# Altium schematic sheet PC+dispDecoder.SchDoc
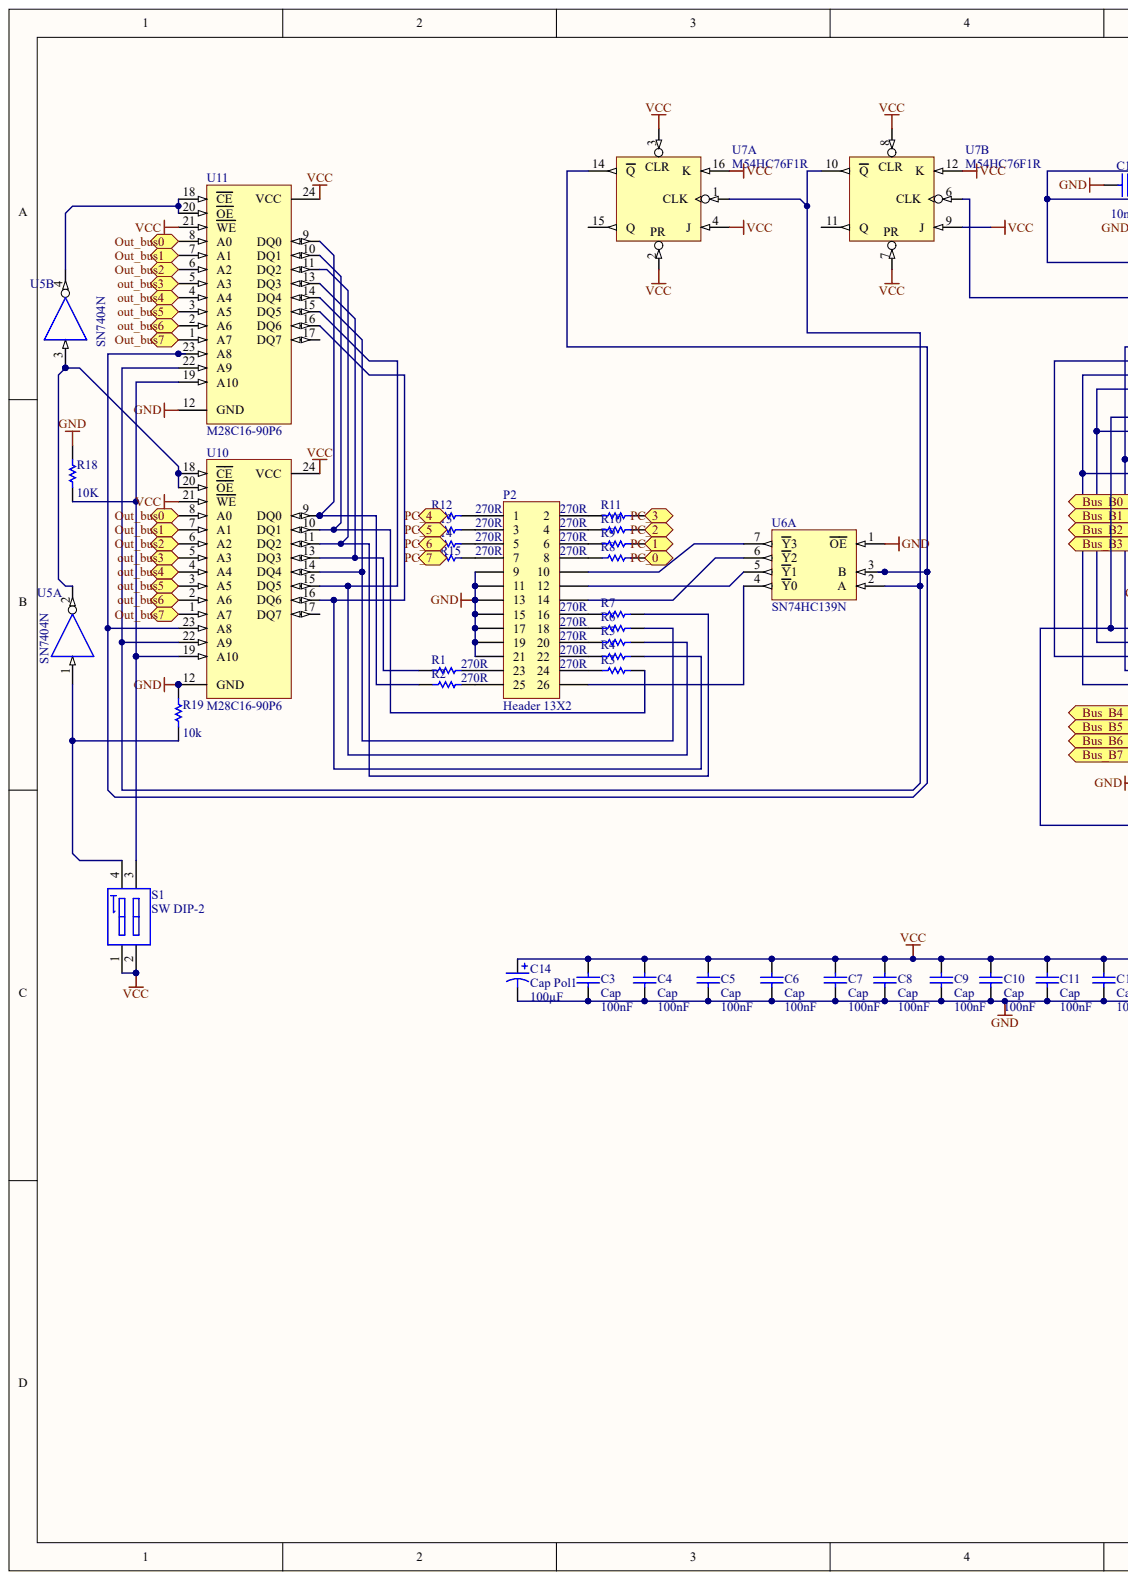
[diagram p0: PC+dispDecoder.SchDoc, left]
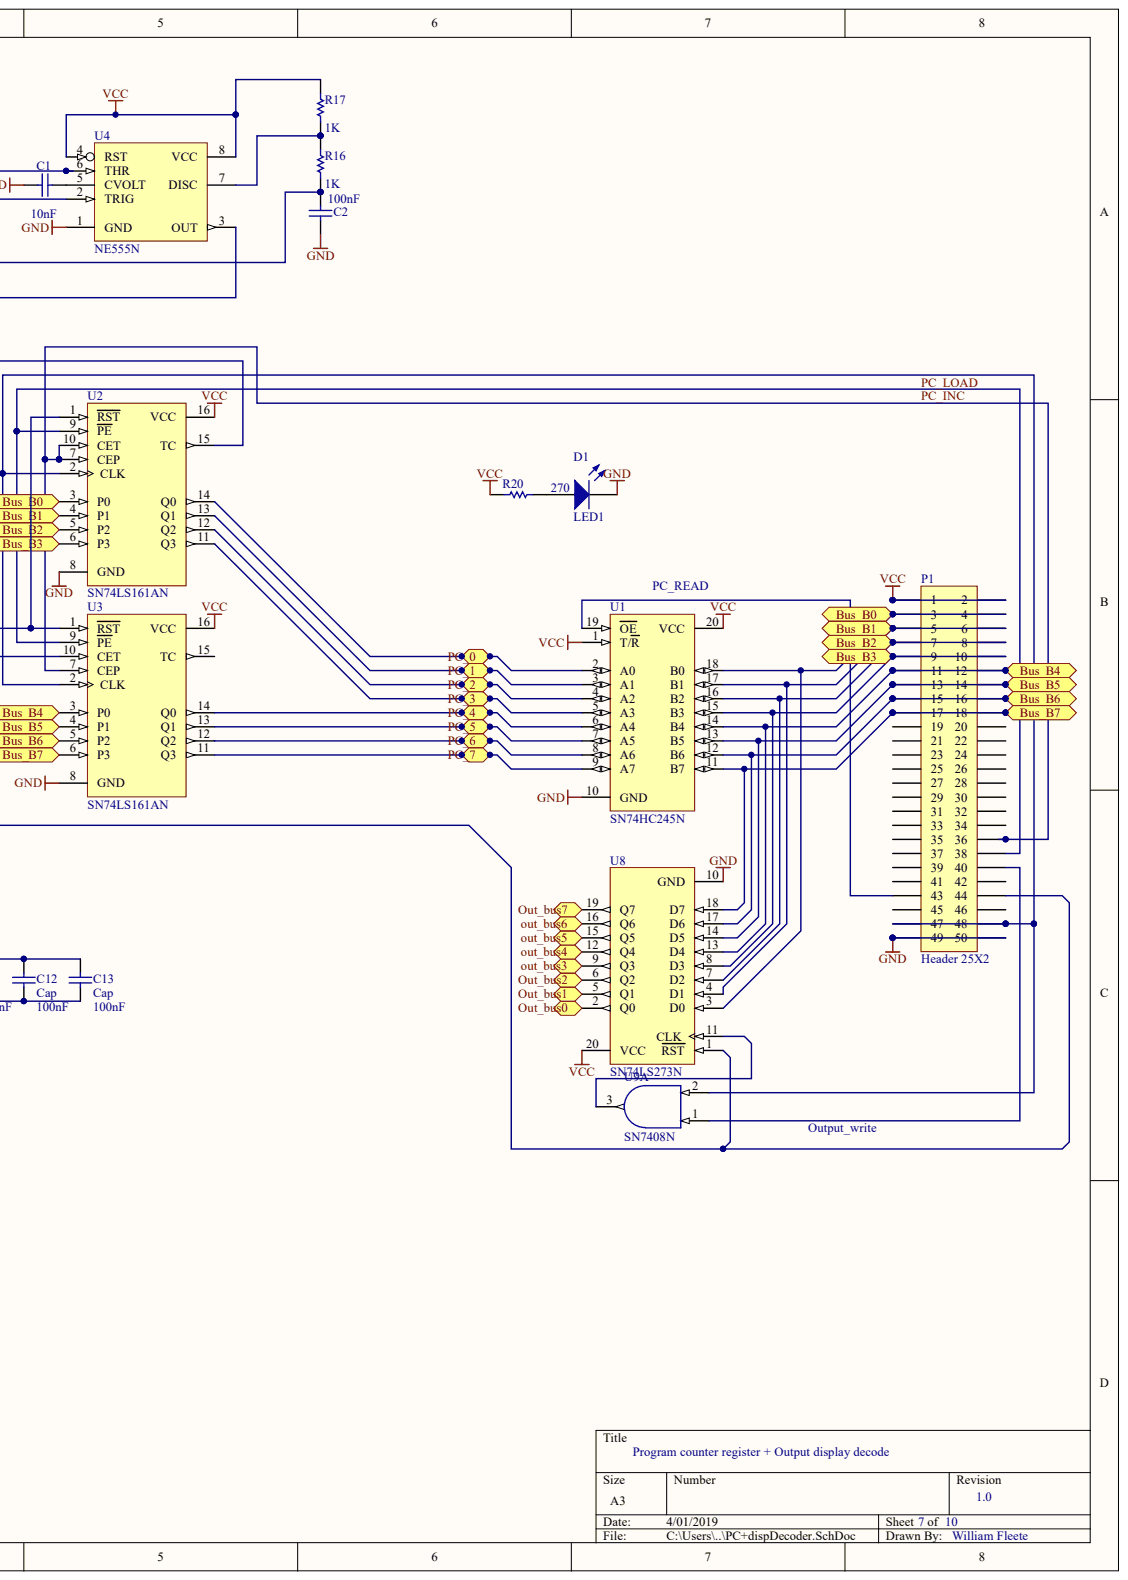
[diagram p1: PC+dispDecoder.SchDoc, right]

## Parts
C1  value 10nF  (hidden comment: Cap)
C2  value 100nF  (hidden comment: Cap)
C3  Cap  value 100nF
C4  Cap  value 100nF
C5  Cap  value 100nF
C6  Cap  value 100nF
C7  Cap  value 100nF
C8  Cap  value 100nF
C9  Cap  value 100nF
C10  Cap  value 100nF
C11  Cap  value 100nF
C12  Cap  value 100nF
C13  Cap  value 100nF
C14  Cap Pol1  value 100µF
D1  LED1
P1  Header 25X2
P2  Header 13X2
R1  value 270R  (hidden comment: Res1)
R2  value 270R  (hidden comment: Res1)
R3  value 270R  (hidden comment: Res1)
R4  value 270R  (hidden comment: Res1)
R5  value 270R  (hidden comment: Res1)
R6  value 270R  (hidden comment: Res1)
R7  value 270R  (hidden comment: Res1)
R8  value 270R  (hidden comment: Res1)
R9  value 270R  (hidden comment: Res1)
R10  value 270R  (hidden comment: Res1)
R11  value 270R  (hidden comment: Res1)
R12  value 270R  (hidden comment: Res1)
R13  value 270R  (hidden comment: Res1)
R14  value 270R  (hidden comment: Res1)
R15  value 270R  (hidden comment: Res1)
R16  value 1K  (hidden comment: Res1)
R17  value 1K  (hidden comment: Res1)
R18  value 10K  (hidden comment: Res1)
R19  value 10k  (hidden comment: Res1)
R20  value 270  (hidden comment: Res1)
S1  SW DIP-2
U1  SN74HC245N
U2  SN74LS161AN
U3  SN74LS161AN
U4  NE555N
U5  SN7404N  (parts A,B)
U6  SN74HC139N  (part A)
U7  M54HC76F1R  (parts A,B)
U8  SN74LS273N
U9  SN7408N  (part A)
U10  M28C16-90P6
U11  M28C16-90P6

## Ports
BUS_B0, BUS_B1, BUS_B2, BUS_B3, BUS_B4, BUS_B5, BUS_B6, BUS_B7, OUT_BUS0, OUT_BUS1, OUT_BUS2, OUT_BUS3, OUT_BUS4, OUT_BUS5, OUT_BUS6, OUT_BUS7, PC_0, PC_1, PC_2, PC_3, PC_4, PC_5, PC_6, PC_7

## Nets
GND: C1.2 C2.1 C3.1 C4.1 C5.1 C6.1 C7.1 C8.1 C9.1 C10.1 C11.1 C12.1 C13.1 C14.2 D1.2 P1.49 P1.50 P2.9 P2.11 P2.13 P2.15 P2.17 P2.19 P2.21 R18.2 R19.2 U1.10(GND) U2.8(GND) U3.8(GND) U4.1(GND) U5.7 U6.1(OE) U6.8 U7.13 U8.10(GND) U9.7 U10.12(GND) U11.12(GND)
VCC: C3.2 C4.2 C5.2 C6.2 C7.2 C8.2 C9.2 C10.2 C11.2 C12.2 C13.2 C14.1 P1.1 P1.2 R17.2 R20.1 S1.1 S1.2 U1.1(T/R) U1.20(VCC) U2.16(VCC) U3.16(VCC) U4.4(RST) U4.8(VCC) U5.14 U6.16 U7.2(PR) U7.3 U7.4(J) U7.5 U7.7(PR) U7.8 U7.9(J) U7.12(K) U7.16(K) U8.20(VCC) U9.14 U10.21(WE) U10.24(VCC) U11.21(WE) U11.24(VCC)
PC_0: R8.2 U1.2(A0) U2.14(Q0) ; port PC_0
PC_1: R9.2 U1.3(A1) U2.13(Q1) ; port PC_1
PC_2: R10.2 U1.4(A2) U2.12(Q2) ; port PC_2
PC_3: R11.2 U1.5(A3) U2.11(Q3) ; port PC_3
PC_4: R12.1 U1.6(A4) U3.14(Q0) ; port PC_4
PC_5: R13.1 U1.7(A5) U3.13(Q1) ; port PC_5
PC_6: R14.1 U1.8(A6) U3.12(Q2) ; port PC_6
PC_7: R15.1 U1.9(A7) U3.11(Q3) ; port PC_7
PC_INC: P1.36 U2.7(CEP) U2.10(CET) U3.7(CEP)
PC_LOAD: P1.38 U2.9(PE) U3.9(PE)
NetC1_1: C1.1 U4.5(CVOLT)
NetC2_2: C2.2 R16.1 U4.2(TRIG) U4.6(THR)
NetD1_1: D1.1 R20.2
NetP1_3: P1.3 P1.4 U1.18(B0) U2.3(P0) U8.3(D0) ; port BUS_B0
NetP1_5: P1.5 P1.6 U1.17(B1) U2.4(P1) U8.4(D1) ; port BUS_B1
NetP1_7: P1.7 P1.8 U1.16(B2) U2.5(P2) U8.7(D2) ; port BUS_B2
NetP1_9: P1.9 P1.10 U1.15(B3) U2.6(P3) U8.8(D3) ; port BUS_B3
NetP1_11: P1.11 P1.12 U1.14(B4) U3.3(P0) U8.13(D4) ; port BUS_B4
NetP1_13: P1.13 P1.14 U1.13(B5) U3.4(P1) U8.14(D5) ; port BUS_B5
NetP1_15: P1.15 P1.16 U1.12(B6) U3.5(P2) U8.17(D6) ; port BUS_B6
NetP1_17: P1.17 P1.18 U1.11(B7) U3.6(P3) U8.18(D7) ; port BUS_B7
NetP1_40: P1.40 U9.1
NetP1_43: P1.43 U1.19(OE)
NetP1_44: P1.44 U2.1(RST) U3.1(RST) U8.1(RST)
NetP1_47: P1.47 P1.48 U2.2(CLK) U3.2(CLK) U9.2
NetP2_1: P2.1 R12.2
NetP2_2: P2.2 R11.1
NetP2_3: P2.3 R13.2
NetP2_4: P2.4 R10.1
NetP2_5: P2.5 R14.2
NetP2_6: P2.6 R9.1
NetP2_7: P2.7 R15.2
NetP2_8: P2.8 R8.1
NetP2_10: P2.10 U6.7(Y3)
NetP2_12: P2.12 U6.5(Y1)
NetP2_14: P2.14 U6.6(Y2)
NetP2_16: P2.16 R7.1
NetP2_18: P2.18 R6.1
NetP2_20: P2.20 R5.1
NetP2_22: P2.22 R4.1
NetP2_23: P2.23 R1.2
NetP2_24: P2.24 R3.1
NetP2_25: P2.25 R2.2
NetP2_26: P2.26 U6.4(Y0)
NetR1_1: R1.1 U10.13(DQ3) U11.13(DQ3)
NetR2_1: R2.1 U10.9(DQ0) U11.9(DQ0)
NetR3_2: R3.2 U10.10(DQ1) U11.10(DQ1)
NetR4_2: R4.2 U10.16(DQ6) U11.16(DQ6)
NetR5_2: R5.2 U10.15(DQ5) U11.15(DQ5)
NetR6_2: R6.2 U10.14(DQ4) U11.14(DQ4)
NetR7_2: R7.2 U10.11(DQ2) U11.11(DQ2)
NetR16_2: R16.2 R17.1 U4.7(DISC)
NetR18_1: R18.1 S1.3 U10.19(A10) U11.19(A10)
NetR19_1: R19.1 S1.4 U5.1
NetU2_15: U2.15(TC) U3.10(CET)
NetU4_3: U4.3(OUT) U7.6(CLK)
NetU5_2: U5.2 U5.3 U10.18(CE) U10.20(OE)
NetU5_4: U5.4 U11.18(CE) U11.20(OE)
NetU6_2: U6.2(A) U7.1(CLK) U7.10(Q) U10.22(A9) U11.22(A9)
NetU6_3: U6.3(B) U7.14(Q) U10.23(A8) U11.23(A8)
NetU8_2: U8.2(Q0) U10.8(A0) U11.8(A0) ; port OUT_BUS0
NetU8_5: U8.5(Q1) U10.7(A1) U11.7(A1) ; port OUT_BUS1
NetU8_6: U8.6(Q2) U10.6(A2) U11.6(A2) ; port OUT_BUS2
NetU8_9: U8.9(Q3) U10.5(A3) U11.5(A3) ; port OUT_BUS3
NetU8_11: U8.11(CLK) U9.3
NetU8_12: U8.12(Q4) U10.4(A4) U11.4(A4) ; port OUT_BUS4
NetU8_15: U8.15(Q5) U10.3(A5) U11.3(A5) ; port OUT_BUS5
NetU8_16: U8.16(Q6) U10.2(A6) U11.2(A6) ; port OUT_BUS6
NetU8_19: U8.19(Q7) U10.1(A7) U11.1(A7) ; port OUT_BUS7

Not connected: P1 19 20 21 22 23 24 25 26 27 28 29 30 31 32 33 34 35 37 39 41 42 45 46; U3 15(TC); U7 11(Q) 15(Q); U10 17(DQ7); U11 17(DQ7)

## Library data of the parts
C1-C14 D1 P1 P2 R1-R20 S1 U1-U11 = (no symbol name): Publisher: Altium Limited
  C1-C13: Published: 8-Jun-2000; LatestRevisionDate: 17-Jul-2002; LatestRevisionNote: Re-released for DXP Platform.; PackageReference: RAD-0.3; PackageDescription: Radial Cap, Thru-Hole; 2 Leads; 0.3 in Pin Spacing
  C14: Published: 8-Jun-2000; LatestRevisionDate: 17-Jul-2002; LatestRevisionNote: Re-released for DXP Platform.; PackageReference: RB7.6-15; PackageDescription: Polarized Capacitor (Radial); 2 Leads
  D1: Published: 8-Jun-2000; LatestRevisionDate: 08-Jul-2005; LatestRevisionNote: Mech Layer 1 removed.; PackageReference: LED-1; PackageDescription: LED; 2 Leads
  P1 P2: LatestRevisionDate: 17-Jul-2002; LatestRevisionNote: Re-released for DXP Platform.
  R1-R20: Published: 8-Jun-2000; LatestRevisionDate: 17-Jul-2002; LatestRevisionNote: Re-released for DXP Platform.; PackageReference: AXIAL-0.3; PackageDescription: Axial Device, Thru-Hole; 2 Leads; 0.3 in Pin Spacing
  S1: Published: 24-Mar-1999; LatestRevisionDate: 17-Jul-2002; LatestRevisionNote: Re-released for DXP Platform.; PackageReference: DIP-4
  U1: Published: 8-Jun-2000; LatestRevisionDate: 04-Mar-2005; LatestRevisionNote: Stylized 3D Model Added.; DatasheetVersion: May-1997; PackageVersion: Oct-1995; PackageReference: N020; PackageDescription: DIP; 20 Leads; Row Spacing 7.62 mm; Pitch 2.54 mm; ComponentLink1Description: Manufacturer Link; ComponentLink1URL: http://www.ti.com/; ComponentLink2Description: Datasheet; ComponentLink2URL: http://www-s.ti.com/sc/ds/sn74hc245.pdf
  U2 U3: Published: 8-Jun-2000; LatestRevisionDate: 04-Mar-2005; LatestRevisionNote: Stylized 3D Model Added.; DatasheetVersion: Mar-1988; PackageVersion: Oct-1995; PackageReference: N016; PackageDescription: DIP; 16 Leads; Row Spacing 7.62 mm; Pitch 2.54 mm; Code_JEDEC: MS-001-BB; ComponentLink1Description: Manufacturer Link; ComponentLink1URL: http://www.ti.com/; ComponentLink2Description: Datasheet; ComponentLink2URL: http://www-s.ti.com/sc/ds/sn74ls161a.pdf; ComponentLink3Description: URL Link1; ComponentLink3URL: http://focus.ti.com/docs/prod/folders/print/sn74ls161a.html
  U4: Published: 24-Aug-2001; LatestRevisionDate: 06-Jun-2005; LatestRevisionNote: Stylized 3D Model Added.; DatasheetVersion: Jul-1998; PackageReference: DIP8; PackageDescription: DIP; 8 Leads; Row Spacing 7.62 mm; Pitch 2.54 mm; Code_JEDEC: MO-001-AN; ComponentLink1Description: Manufacturer Link; ComponentLink1URL: http://www.st.com/; ComponentLink2Description: Datasheet; ComponentLink2URL: http://www.st.com/stonline/products/literature/ds/2182/ne555.pdf
  U5: Published: 8-Jun-2000; LatestRevisionDate: 04-Mar-2005; LatestRevisionNote: Stylized 3D Model Added.; DatasheetVersion: Mar-1988; PackageVersion: Oct-1995; PackageReference: N014; PackageDescription: DIP; 14 Leads; Row Spacing 7.62 mm; Pitch 2.54 mm; ComponentLink1Description: Manufacturer Link; ComponentLink1URL: http://www.ti.com/; ComponentLink2Description: Datasheet; ComponentLink2URL: http://www-s.ti.com/sc/ds/sn5404.pdf; ComponentLink3Description: Packaging Specification; ComponentLink3URL: http://focus.ti.com/lit/ml/mpdi002c/mpdi002c.pdf
  U6: Published: 8-Jun-2000; LatestRevisionDate: 04-Mar-2005; LatestRevisionNote: Stylized 3D Model Added.; DatasheetVersion: May-1997; PackageVersion: Oct-1995; PackageReference: N016; PackageDescription: DIP; 16 Leads; Row Spacing 7.62 mm; Pitch 2.54 mm; Code_JEDEC: MS-001-BB; ComponentLink1Description: Manufacturer Link; ComponentLink1URL: http://www.ti.com/; ComponentLink2Description: Datasheet; ComponentLink2URL: http://www-s.ti.com/sc/ds/sn74hc139.pdf
  U7: Published: 8-Jun-2000; LatestRevisionDate: 06-Jun-2005; LatestRevisionNote: Stylized 3D Model Added.; DatasheetVersion: Oct-1992; PackageVersion: Apr-1996; PackageReference: DIP16; PackageDescription: DIP; 16 Leads; Row Spacing 7.62 mm; Pitch 2.54 mm; ComponentLink1Description: Manufacturer Link; ComponentLink1URL: http://www.st.com/
  U8: Published: 8-Jun-2000; LatestRevisionDate: 04-Mar-2005; LatestRevisionNote: Stylized 3D Model Added.; DatasheetVersion: Mar-1988; PackageVersion: Oct-1995; PackageReference: N020; PackageDescription: DIP; 20 Leads; Row Spacing 7.62 mm; Pitch 2.54 mm; ComponentLink1Description: Manufacturer Link; ComponentLink1URL: http://www.ti.com/; ComponentLink2Description: Datasheet; ComponentLink2URL: http://www-s.ti.com/sc/ds/sn74273.pdf
  U9: Published: 8-Jun-2000; LatestRevisionDate: 04-Mar-2005; LatestRevisionNote: Stylized 3D Model Added.; DatasheetVersion: Mar-1988; PackageVersion: Oct-1995; PackageReference: N014; PackageDescription: DIP; 14 Leads; Row Spacing 7.62 mm; Pitch 2.54 mm; ComponentLink1Description: Manufacturer Link; ComponentLink1URL: http://www.ti.com/; ComponentLink2Description: Datasheet; ComponentLink2URL: http://www-s.ti.com/sc/ds/sn54ls08.pdf; ComponentLink3Description: Packaging Specification; ComponentLink3URL: http://focus.ti.com/lit/ml/mpdi002c/mpdi002c.pdf
  U10 U11: Published: 24-Aug-2001; LatestRevisionDate: 06-Jun-2005; LatestRevisionNote: Stylized 3D Model Added.; DatasheetVersion: Nov-1997; PackageReference: PDIP24; PackageDescription: DIP; 24 Leads; Row Spacing 15.24 mm; Pitch 2.54 mm; ComponentLink1Description: Manufacturer Link; ComponentLink1URL: http://www.st.com/
